# Altium schematic sheet EVB-NORA-B2_SCHEM_0006_PinHeaders_ULED.SchDoc(EVB-NORA-B2_SCHEM_0006_PinHeaders_ULED)
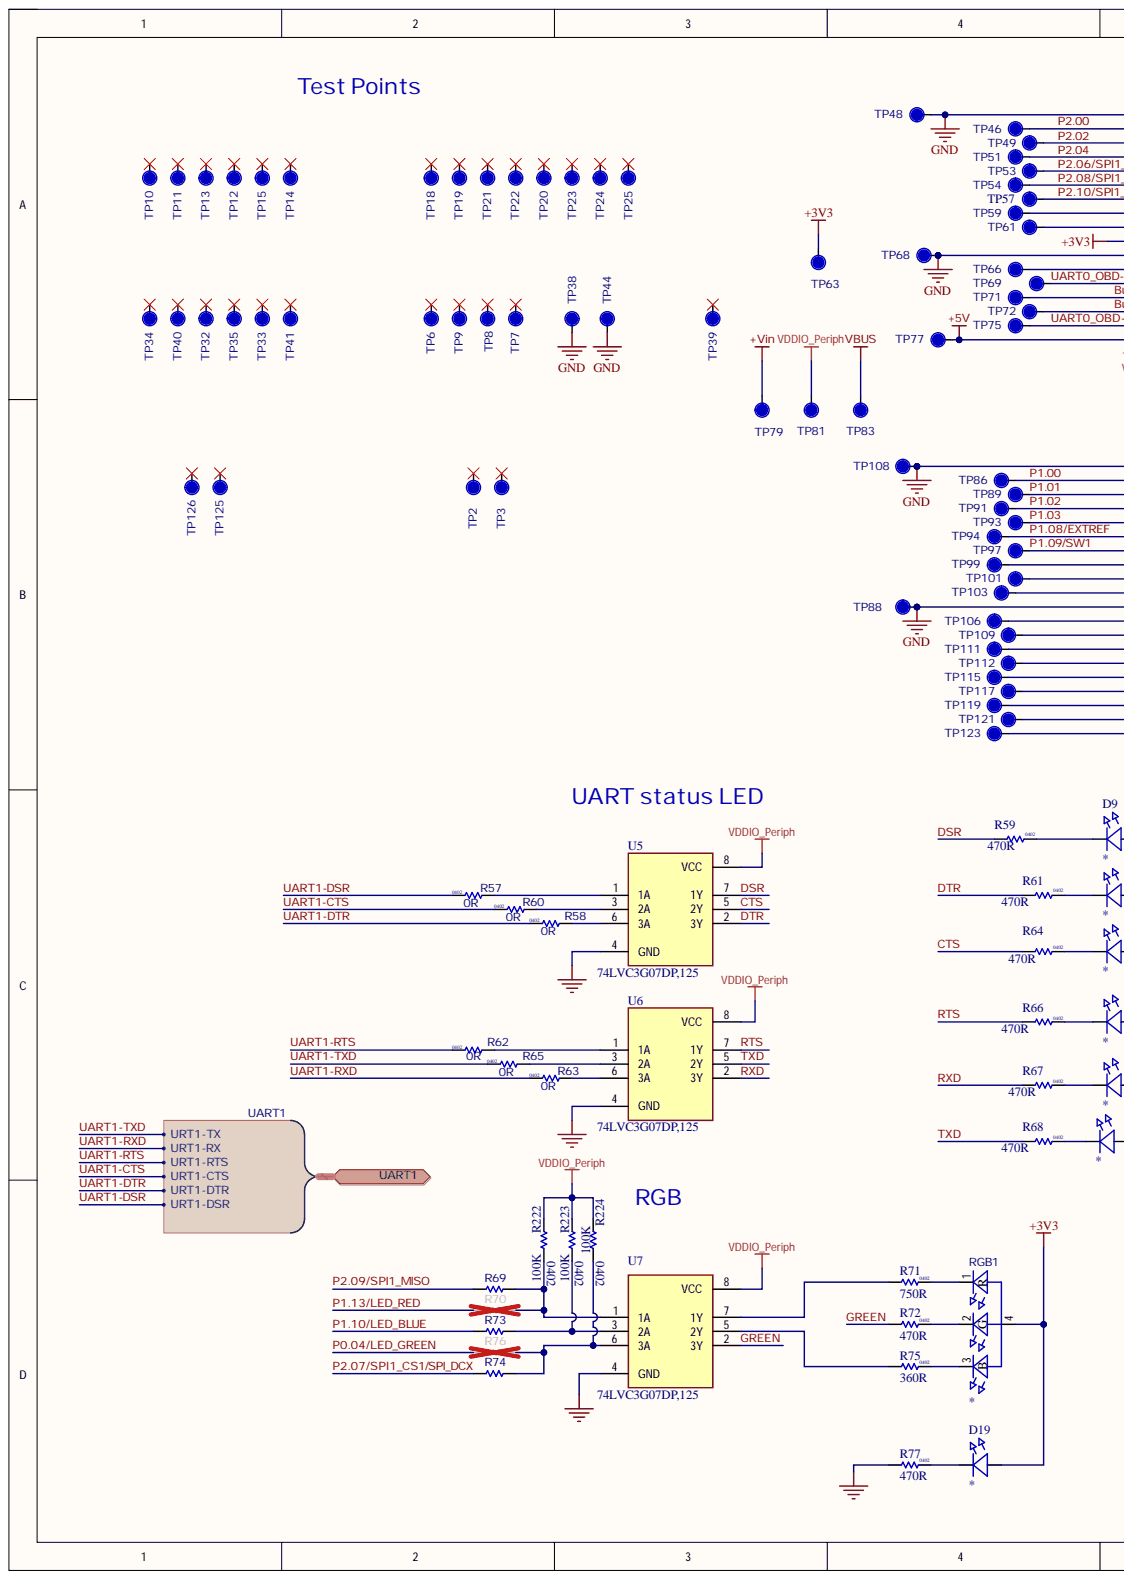
[diagram p0: EVB-NORA-B2_SCHEM_0006_PinHeaders_ULED.SchDoc, left]
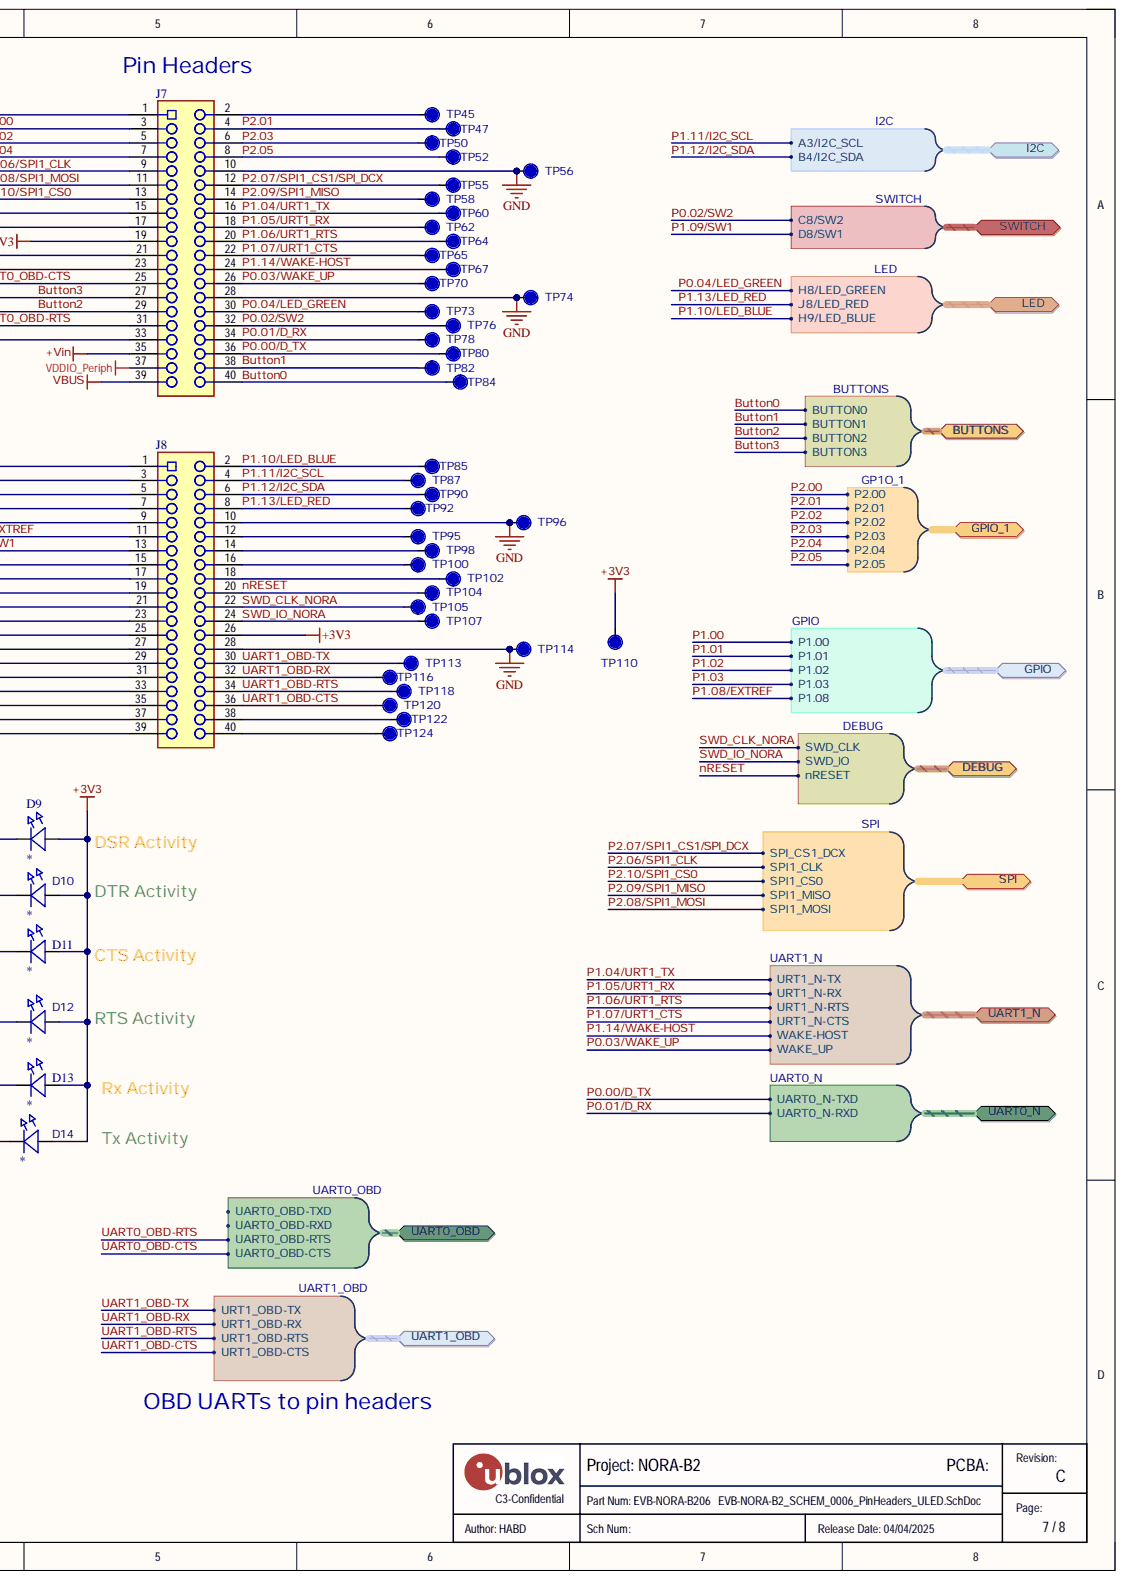
[diagram p1: EVB-NORA-B2_SCHEM_0006_PinHeaders_ULED.SchDoc, right]

## Parts
D9  UBXH11-0000057  [LED_0603_YELLOW]  (value not drawn)
D10  UBXH11-0000001  [LED_0603_GREEN]  (value not drawn)
D11  UBXH11-0000057  [LED_0603_YELLOW]  (value not drawn)
D12  UBXH11-0000001  [LED_0603_GREEN]  (value not drawn)
D13  UBXH11-0000057  [LED_0603_YELLOW]  (value not drawn)
D14  UBXH11-0000001  [LED_0603_GREEN]  (value not drawn)
D19  UBXH11-0000018  [LED_0603_1600Lx800Wx400H_600x800Pad_800G_FLX]  (value not drawn)
J7  (no value)  [M208762042]
J8  (no value)  [M208762042]
R57  value 0R  Size: 0402  [RES 0402 HD]  (hidden comment: UBXH18-0003002)
R58  value 0R  Size: 0402  [RES 0402 HD]
R59  value 470R  Size: 0402  [RES_0402_1100Lx600Wx400H_500x600Pad_400G_FLX]  (hidden comment: UBXH18-0008041)
R60  value 0R  Size: 0402  [RES 0402 HD]  (hidden comment: UBXH18-0003002)
R61  value 470R  Size: 0402  [RES_0402_1100Lx600Wx400H_500x600Pad_400G_FLX]  (hidden comment: UBXH18-0008041)
R62  value 0R  Size: 0402  [RES 0402 HD]  (hidden comment: UBXH18-0003002)
R63  value 0R  Size: 0402  [RES 0402 HD]  (hidden comment: UBXH18-0003002)
R64  value 470R  Size: 0402  [RES_0402_1100Lx600Wx400H_500x600Pad_400G_FLX]  (hidden comment: UBXH18-0008041)
R65  value 0R  Size: 0402  [RES 0402 HD]  (hidden comment: UBXH18-0003002)
R66  value 470R  Size: 0402  [RES_0402_1100Lx600Wx400H_500x600Pad_400G_FLX]  (hidden comment: UBXH18-0008041)
R67  value 470R  Size: 0402  [RES_0402_1100Lx600Wx400H_500x600Pad_400G_FLX]  (hidden comment: UBXH18-0008041)
R68  value 470R  Size: 0402  [RES_0402_1100Lx600Wx400H_500x600Pad_400G_FLX]  (hidden comment: UBXH18-0008041)
R69  UBXH18-0003002  [RES 0402 HD]  (value not drawn)
R70  = "Part Number" + Value + DNP  [RES 0402 HD]
R71  value 750R  Size: 0402  [RES_0402_1100Lx600Wx400H_500x600Pad_400G_FLX]  (hidden comment: UBXH18-0008046)
R72  value 470R  Size: 0402  [RES_0402_1100Lx600Wx400H_500x600Pad_400G_FLX]  (hidden comment: UBXH18-0008041)
R73  UBXH18-0003002  [RES 0402 HD]  (value not drawn)
R74  UBXH18-0003002  [RES 0402 HD]  (value not drawn)
R75  value 360R  Size: 0402  [RES_0402_1100Lx600Wx400H_500x600Pad_400G_FLX]  (hidden comment: UBXH18-0000216)
R76  = "Part Number" + Value + DNP  [RES 0402 HD]
R77  value 470R  Size: 0402  [RES_0402_1100Lx600Wx400H_500x600Pad_400G_FLX]  (hidden comment: UBXH18-0008041)
R222  value 100K  Size: 0402  [RES 0402 HD]  (hidden comment: UBXH18-0000005)
R223  value 100K  Size: 0402  [RES 0402 HD]  (hidden comment: UBXH18-0000005)
R224  value 100K  Size: 0402  [RES 0402 HD]  (hidden comment: UBXH18-0000005)
RGB1  115-00026  [LED_1616]  (value not drawn)
TP2  TP10R  [TP10R]  (value not drawn)  (no BOM)
TP3  TP10R  [TP10R]  (value not drawn)  (no BOM)
TP6  TP10R  [TP10R]  (value not drawn)  (no BOM)
TP7  TP10R  [TP10R]  (value not drawn)  (no BOM)
TP8  TP10R  [TP10R]  (value not drawn)  (no BOM)
TP9  TP10R  [TP10R]  (value not drawn)  (no BOM)
TP10  TP10R  [TP10R]  (value not drawn)  (no BOM)
TP11  TP10R  [TP10R]  (value not drawn)  (no BOM)
TP12  TP10R  [TP10R]  (value not drawn)  (no BOM)
TP13  TP10R  [TP10R]  (value not drawn)  (no BOM)
TP14  TP10R  [TP10R]  (value not drawn)  (no BOM)
TP15  TP10R  [TP10R]  (value not drawn)  (no BOM)
TP18  TP10R  [TP10R]  (value not drawn)  (no BOM)
TP19  TP10R  [TP10R]  (value not drawn)  (no BOM)
TP20  TP10R  [TP10R]  (value not drawn)  (no BOM)
TP21  TP10R  [TP10R]  (value not drawn)  (no BOM)
TP22  TP10R  [TP10R]  (value not drawn)  (no BOM)
TP23  TP10R  [TP10R]  (value not drawn)  (no BOM)
TP24  TP10R  [TP10R]  (value not drawn)  (no BOM)
TP25  TP10R  [TP10R]  (value not drawn)  (no BOM)
TP32  TP10R  [TP10R]  (value not drawn)  (no BOM)
TP33  TP10R  [TP10R]  (value not drawn)  (no BOM)
TP34  TP10R  [TP10R]  (value not drawn)  (no BOM)
TP35  TP10R  [TP10R]  (value not drawn)  (no BOM)
TP38  TP10R  [TP10R]  (value not drawn)  (no BOM)
TP39  TP10R  [TP10R]  (value not drawn)  (no BOM)
TP40  TP10R  [TP10R]  (value not drawn)  (no BOM)
TP41  TP10R  [TP10R]  (value not drawn)  (no BOM)
TP44  TP10R  [TP10R]  (value not drawn)  (no BOM)
TP45  TP10R  [TP10R]  (value not drawn)  (no BOM)
TP46  TP10R  [TP10R]  (value not drawn)  (no BOM)
TP47  TP10R  [TP10R]  (value not drawn)  (no BOM)
TP48  TP10R  [TP10R]  (value not drawn)  (no BOM)
TP49  TP10R  [TP10R]  (value not drawn)  (no BOM)
TP50  TP10R  [TP10R]  (value not drawn)  (no BOM)
TP51  TP10R  [TP10R]  (value not drawn)  (no BOM)
TP52  TP10R  [TP10R]  (value not drawn)  (no BOM)
TP53  TP10R  [TP10R]  (value not drawn)  (no BOM)
TP54  TP10R  [TP10R]  (value not drawn)  (no BOM)
TP55  TP10R  [TP10R]  (value not drawn)  (no BOM)
TP56  TP10R  [TP10R]  (value not drawn)  (no BOM)
TP57  TP10R  [TP10R]  (value not drawn)
TP58  TP10R  [TP10R]  (value not drawn)  (no BOM)
TP59  TP10R  [TP10R]  (value not drawn)  (no BOM)
TP60  TP10R  [TP10R]  (value not drawn)  (no BOM)
TP61  TP10R  [TP10R]  (value not drawn)  (no BOM)
TP62  TP10R  [TP10R]  (value not drawn)  (no BOM)
TP63  TP10R  [TP10R]  (value not drawn)  (no BOM)
TP64  TP10R  [TP10R]  (value not drawn)  (no BOM)
TP65  TP10R  [TP10R]  (value not drawn)  (no BOM)
TP66  TP10R  [TP10R]  (value not drawn)  (no BOM)
TP67  TP10R  [TP10R]  (value not drawn)  (no BOM)
TP68  TP10R  [TP10R]  (value not drawn)  (no BOM)
TP69  TP10R  [TP10R]  (value not drawn)  (no BOM)
TP70  TP10R  [TP10R]  (value not drawn)  (no BOM)
TP71  TP10R  [TP10R]  (value not drawn)  (no BOM)
TP72  TP10R  [TP10R]  (value not drawn)  (no BOM)
TP73  TP10R  [TP10R]  (value not drawn)  (no BOM)
TP74  TP10R  [TP10R]  (value not drawn)  (no BOM)
TP75  TP10R  [TP10R]  (value not drawn)  (no BOM)
TP76  TP10R  [TP10R]  (value not drawn)  (no BOM)
TP77  TP10R  [TP10R]  (value not drawn)  (no BOM)
TP78  TP10R  [TP10R]  (value not drawn)  (no BOM)
TP79  TP10R  [TP10R]  (value not drawn)  (no BOM)
TP80  TP10R  [TP10R]  (value not drawn)  (no BOM)
TP81  TP10R  [TP10R]  (value not drawn)  (no BOM)
TP82  TP10R  [TP10R]  (value not drawn)  (no BOM)
TP83  TP10R  [TP10R]  (value not drawn)  (no BOM)
TP84  TP10R  [TP10R]  (value not drawn)  (no BOM)
TP85  TP10R  [TP10R]  (value not drawn)  (no BOM)
TP86  TP10R  [TP10R]  (value not drawn)  (no BOM)
TP87  TP10R  [TP10R]  (value not drawn)  (no BOM)
TP88  TP10R  [TP10R]  (value not drawn)  (no BOM)
TP89  TP10R  [TP10R]  (value not drawn)  (no BOM)
TP90  TP10R  [TP10R]  (value not drawn)  (no BOM)
TP91  TP10R  [TP10R]  (value not drawn)  (no BOM)
TP92  TP10R  [TP10R]  (value not drawn)  (no BOM)
TP93  TP10R  [TP10R]  (value not drawn)  (no BOM)
TP94  TP10R  [TP10R]  (value not drawn)  (no BOM)
TP95  TP10R  [TP10R]  (value not drawn)  (no BOM)
TP96  TP10R  [TP10R]  (value not drawn)  (no BOM)
TP97  TP10R  [TP10R]  (value not drawn)  (no BOM)
TP98  TP10R  [TP10R]  (value not drawn)  (no BOM)
TP99  TP10R  [TP10R]  (value not drawn)  (no BOM)
TP100  TP10R  [TP10R]  (value not drawn)  (no BOM)
TP101  TP10R  [TP10R]  (value not drawn)  (no BOM)
TP102  TP10R  [TP10R]  (value not drawn)  (no BOM)
TP103  TP10R  [TP10R]  (value not drawn)  (no BOM)
TP104  TP10R  [TP10R]  (value not drawn)  (no BOM)
TP105  TP10R  [TP10R]  (value not drawn)  (no BOM)
TP106  TP10R  [TP10R]  (value not drawn)  (no BOM)
TP107  TP10R  [TP10R]  (value not drawn)  (no BOM)
TP108  TP10R  [TP10R]  (value not drawn)  (no BOM)
TP109  TP10R  [TP10R]  (value not drawn)  (no BOM)
TP110  TP10R  [TP10R]  (value not drawn)  (no BOM)
TP111  TP10R  [TP10R]  (value not drawn)  (no BOM)
TP112  TP10R  [TP10R]  (value not drawn)  (no BOM)
TP113  TP10R  [TP10R]  (value not drawn)  (no BOM)
TP114  TP10R  [TP10R]  (value not drawn)  (no BOM)
TP115  TP10R  [TP10R]  (value not drawn)  (no BOM)
TP116  TP10R  [TP10R]  (value not drawn)  (no BOM)
TP117  TP10R  [TP10R]  (value not drawn)  (no BOM)
TP118  TP10R  [TP10R]  (value not drawn)  (no BOM)
TP119  TP10R  [TP10R]  (value not drawn)  (no BOM)
TP120  TP10R  [TP10R]  (value not drawn)  (no BOM)
TP121  TP10R  [TP10R]  (value not drawn)  (no BOM)
TP122  TP10R  [TP10R]  (value not drawn)  (no BOM)
TP123  TP10R  [TP10R]  (value not drawn)  (no BOM)
TP124  TP10R  [TP10R]  (value not drawn)  (no BOM)
TP125  TP10R  [TP10R]  (value not drawn)  (no BOM)
TP126  TP10R  [TP10R]  (value not drawn)  (no BOM)
U5  Arrow Part Number: 74LVC3G07DP,125  [SOP65P400X110-8N]  (hidden comment: UBXH06-0000120)
U6  Arrow Part Number: 74LVC3G07DP,125  [SOP65P400X110-8N]  (hidden comment: UBXH06-0000120)
U7  Arrow Part Number: 74LVC3G07DP,125  [SOP65P400X110-8N]  (hidden comment: UBXH06-0000120)

## Ports
BUTTONS, BUTTONS.BUTTON0, BUTTONS.BUTTON1, BUTTONS.BUTTON2, BUTTONS.BUTTON3, DEBUG, DEBUG.nRESET, DEBUG.SWD_CLK, DEBUG.SWD_IO, GPIO, GPIO.P1.00, GPIO.P1.01, GPIO.P1.02, GPIO.P1.03, GPIO.P1.08, GPIO_1, GPIO_1.P2.00, GPIO_1.P2.01, GPIO_1.P2.02, GPIO_1.P2.03, GPIO_1.P2.04, GPIO_1.P2.05, I2C, I2C.A3/I2C_SCL, I2C.B4/I2C_SDA, LED, LED.H8/LED_GREEN, LED.H9/LED_BLUE, LED.J8/LED_RED, SPI, SPI.SPI1_CLK, SPI.SPI1_CS0, SPI.SPI1_MISO, SPI.SPI1_MOSI, SPI.SPI_CS1_DCX, SWITCH, SWITCH.C8/SW2, SWITCH.D8/SW1, UART0_N, UART0_N.UART0_N-RXD, UART0_N.UART0_N-TXD, UART0_OBD, UART0_OBD.UART0_OBD-CTS, UART0_OBD.UART0_OBD-RTS, UART0_OBD.UART0_OBD-RXD, UART0_OBD.UART0_OBD-TXD, UART1, UART1.URT1-CTS, UART1.URT1-DSR, UART1.URT1-DTR, UART1.URT1-RTS, UART1.URT1-RX, UART1.URT1-TX, UART1_N, UART1_N.URT1_N-CTS, UART1_N.URT1_N-RTS, UART1_N.URT1_N-RX, UART1_N.URT1_N-TX, UART1_N.WAKE-HOST, UART1_N.WAKE_UP, UART1_OBD, UART1_OBD.URT1_OBD-CTS, UART1_OBD.URT1_OBD-RTS, UART1_OBD.URT1_OBD-RX, UART1_OBD.URT1_OBD-TX

## Nets
+3V3: D9.2 D10.2 D11.2 D12.2 D13.2 D14.2 D19.2 J7.19 J8.26 RGB1.4 TP63.1 TP110.1
+5V: J7.33 TP77.1
+Vin: J7.35 TP79.1
GND: J7.1 J7.10 J7.21 J7.28 J8.1 J8.10 J8.21 J8.28 R77.1 TP38.1 TP44.1 TP48.1 TP56.1 TP68.1 TP74.1 TP88.1 TP96.1 TP108.1 TP114.1 U5.4(GND) U6.4(GND) U7.4(GND)
VBUS: J7.39 TP83.1
VDDIO_Periph: J7.37 R222.1 R223.1 R224.1 TP81.1 U5.8(VCC) U6.8(VCC) U7.8(VCC)
Button0: J7.40 TP84.1 ; port BUTTONS
Button1: J7.38 TP82.1 ; port BUTTONS
Button2: J7.29 TP72.1 ; port BUTTONS
Button3: J7.27 TP71.1 ; port BUTTONS
CTS: R64.1 U5.5(2Y)
DSR: R59.1 U5.7(1Y)
DTR: R61.1 U5.2(3Y)
GREEN: R72.1 U7.2(3Y)
nRESET: J8.20 TP104.1 ; port DEBUG
P0.00/D_TX: J7.36 TP80.1 ; port UART0_N
P0.01/D_RX: J7.34 TP78.1 ; port UART0_N
P0.02/SW2: J7.32 TP76.1 ; port SWITCH
P0.03/WAKE_UP: J7.26 TP70.1 ; port UART1_N
P0.04/LED_GREEN: J7.30 R76.2 TP73.1 ; port LED
P1.00: J8.3 TP86.1 ; port GPIO
P1.01: J8.5 TP89.1 ; port GPIO
P1.02: J8.7 TP91.1 ; port GPIO
P1.03: J8.9 TP93.1 ; port GPIO
P1.04/URT1_TX: J7.16 TP60.1 ; port UART1_N
P1.05/URT1_RX: J7.18 TP62.1 ; port UART1_N
P1.06/URT1_RTS: J7.20 TP64.1 ; port UART1_N
P1.07/URT1_CTS: J7.22 TP65.1 ; port UART1_N
P1.08/EXTREF: J8.11 TP94.1 ; port GPIO
P1.09/SW1: J8.13 TP97.1 ; port SWITCH
P1.10/LED_BLUE: J8.2 R73.2 TP85.1 ; port LED
P1.11/I2C_SCL: J8.4 TP87.1 ; port I2C
P1.12/I2C_SDA: J8.6 TP90.1 ; port I2C
P1.13/LED_RED: J8.8 R70.2 TP92.1 ; port LED
P1.14/WAKE-HOST: J7.24 TP67.1 ; port UART1_N
P2.00: J7.3 TP46.1 ; port GPIO_1
P2.01: J7.4 TP47.1 ; port GPIO_1
P2.02: J7.5 TP49.1 ; port GPIO_1
P2.03: J7.6 TP50.1 ; port GPIO_1
P2.04: J7.7 TP51.1 ; port GPIO_1
P2.05: J7.8 TP52.1 ; port GPIO_1
P2.06/SPI1_CLK: J7.9 TP53.1 ; port SPI
P2.07/SPI1_CS1/SPI_DCX: J7.12 R74.2 TP55.1 ; port SPI
P2.08/SPI1_MOSI: J7.11 TP54.1 ; port SPI
P2.09/SPI1_MISO: J7.14 R69.2 TP58.1 ; port SPI
P2.10/SPI1_CS0: J7.13 TP57.1 ; port SPI
RTS: R66.1 U6.7(1Y)
RXD: R67.1 U6.2(3Y)
SWD_CLK_NORA: J8.22 TP105.1 ; port DEBUG
SWD_IO_NORA: J8.24 TP107.1 ; port DEBUG
TXD: R68.1 U6.5(2Y)
UART0_OBD-CTS: J7.25 TP69.1 ; port UART0_OBD
UART0_OBD-RTS: J7.31 TP75.1 ; port UART0_OBD
UART1-CTS: R60.2 ; port UART1
UART1-DSR: R57.2 ; port UART1
UART1-DTR: R58.2 ; port UART1
UART1-RTS: R62.2 ; port UART1
UART1-RXD: R63.2 ; port UART1
UART1-TXD: R65.2 ; port UART1
UART1_OBD-CTS: J8.36 TP120.1 ; port UART1_OBD
UART1_OBD-RTS: J8.34 TP118.1 ; port UART1_OBD
UART1_OBD-RX: J8.32 TP116.1 ; port UART1_OBD
UART1_OBD-TX: J8.30 TP113.1 ; port UART1_OBD
NetD9_1: D9.1 R59.2
NetD10_1: D10.1 R61.2
NetD11_1: D11.1 R64.2
NetD12_1: D12.1 R66.2
NetD13_1: D13.1 R67.2
NetD14_1: D14.1 R68.2
NetD19_1: D19.1 R77.2
NetJ7_2: J7.2 TP45.1
NetJ7_15: J7.15 TP59.1
NetJ7_17: J7.17 TP61.1
NetJ7_23: J7.23 TP66.1
NetJ8_12: J8.12 TP95.1
NetJ8_14: J8.14 TP98.1
NetJ8_15: J8.15 TP99.1
NetJ8_16: J8.16 TP100.1
NetJ8_17: J8.17 TP101.1
NetJ8_18: J8.18 TP102.1
NetJ8_19: J8.19 TP103.1
NetJ8_23: J8.23 TP106.1
NetJ8_25: J8.25 TP109.1
NetJ8_27: J8.27 TP111.1
NetJ8_29: J8.29 TP112.1
NetJ8_31: J8.31 TP115.1
NetJ8_33: J8.33 TP117.1
NetJ8_35: J8.35 TP119.1
NetJ8_37: J8.37 TP121.1
NetJ8_38: J8.38 TP122.1
NetJ8_39: J8.39 TP123.1
NetJ8_40: J8.40 TP124.1
NetR57_1: R57.1 U5.1(1A)
NetR58_1: R58.1 U5.6(3A)
NetR60_1: R60.1 U5.3(2A)
NetR62_1: R62.1 U6.1(1A)
NetR63_1: R63.1 U6.6(3A)
NetR65_1: R65.1 U6.3(2A)
NetR69_1: R69.1 R70.1 R222.2 U7.1(1A)
NetR71_1: R71.1 U7.7(1Y)
NetR71_2: R71.2 RGB1.1(R)
NetR72_2: R72.2 RGB1.2(G)
NetR73_1: R73.1 R223.2 U7.3(2A)
NetR74_1: R74.1 R76.1 R224.2 U7.6(3A)
NetR75_1: R75.1 U7.5(2Y)
NetR75_2: R75.2 RGB1.3(B)
N00022: ; port UART0_OBD
N00023: ; port UART0_OBD

Not connected: TP2 1; TP3 1; TP6 1; TP7 1; TP8 1; TP9 1; TP10 1; TP11 1; TP12 1; TP13 1; TP14 1; TP15 1; TP18 1; TP19 1; TP20 1; TP21 1; TP22 1; TP23 1; TP24 1; TP25 1; TP32 1; TP33 1; TP34 1; TP35 1; TP39 1; TP40 1; TP41 1; TP125 1; TP126 1

## Library data of the parts
D9 D11 D13 = UBXH11-0000057: DIODE LED SMT YELLOW CLEAR LENS 2.1V 10MA 0603 YELLOW; Manufacturer: Lite-on Technology Corporation; Manufacturer P/N: LTST-C190YKT; Database Table Name: Discrete - LED; Editor: eric.moog; Env: GREEN; Mfg P/N Released: No; Mfg P/N Split: 100; Mfg P/N Status: Active; Permitted Use: Volume Products; Component Kind: Standard; Library Name: UBX_Parts_DB_Lib.DbLib; Max Operating Temp: 85°C; Mfg P/N Datasheet: https://wiki.u-blox.com/bin/view/Generic/Docs/DocumentSummary?index=38122; Min Operating Temp: -55°C; Part Number: UBXH11-0000057; Part Type: LED; Perferred: N/A; RefDes: DS; Size: 0603; UBX Wiki: https://wiki.u-blox.com/bin/view/Operations/PartDetails?index=38120; Value: YELLOW
D10 D12 D14 = UBXH11-0000001: LED SMD 0603 GREEN 2.5V 20mA; Manufacturer: Kingbright; Manufacturer P/N: APT1608SGC; Database Table Name: Discrete - LED; Editor: gianni.falleroni; Env: ROHS; Mfg P/N Released: Yes; Mfg P/N Split: 50; Mfg P/N Status: Active; Permitted Use: Support Products; Released by: alex.claville; Component Kind: Standard; Library Name: UBX_Parts_DB_Lib.DbLib; Manufacturer 2: Lite-on Technology Corporation; Manufacturer P/N 2: LTST-C190KGKT; Max Current: 20mA; Max Voltage: 2.5V; Mfg P/N Datasheet: https://wiki.u-blox.com/bin/view/Generic/Docs/DocumentSummary?index=37527; Mfg P/N Datasheet 2: https://wiki.u-blox.com/bin/view/Generic/Docs/DocumentSummary?index=16220; Mfg P/N Released 2: Yes; Mfg P/N Split 2: 50; Mfg P/N Status 2: Active; Part Number: UBXH11-0000001; Part Type: LED; Perferred: N/A; RefDes: DS; Release Data: 2022-09-27T14:26:54; Size: 0603; UBX Wiki: https://wiki.u-blox.com/bin/view/Operations/PartDetails?index=7902; Value: GREEN
D19 = UBXH11-0000018: LED CHIP 0603 LOW CURRENT OSRAM 0.002A GREEN 2.2V 2mA; Manufacturer P/N: LG L29K-G2J1-24; Database Table Name: Discrete - LED; Editor: johann.furrer; Env: ROHS; Mfg P/N Released: Yes; Mfg P/N Split: 100; Mfg P/N Status: NRND; Perferred: PREF; Permitted Use: Volume Products; Released by: martin.grasse; Component Kind: Standard; Library Name: UBX_Parts_DB_Lib.DbLib; Manufacturer: Osram; Max Current: 2mA; Max Operating Temp: 85°C; Max Voltage: 2.2V; Mfg P/N Datasheet: https://wiki.u-blox.com/bin/view/Generic/Docs/DocumentSummary?index=20620; Min Operating Temp: -40°C; Part Number: UBXH11-0000018; Part Type: LED; RefDes: DS; Release Data: 2018-01-09T15:31:38; Size: 0603; UBX Wiki: https://wiki.u-blox.com/bin/view/Operations/PartDetails?index=20619; Value: GREEN
J7 J8 = M20-8762042: Connector; Manufacturer_Name: Harwin; Component Kind: Standard; Datasheet Link: https://cdn.harwin.com/pdfs/M20-876.pdf; Height: 10.01mm; Library Name: SamacSys.SchLib; Manufacturer_Part_Number: M20-8762042; Mouser Part Number: 855-M20-8762042; Mouser Price/Stock: https://www.mouser.co.uk/ProductDetail/Harwin/M20-8762042?qs=vF78I%252BjhbY%2F5WnwPzhFszw%3D%3D
R57 R58 R60 R62 R63 R65 R69 R70 R73 R74 R76 = UBXH18-0003002: RES THICK FILM CHIP 0402 0R 0 100mW; Manufacturer: KOA; Manufacturer P/N: RK73Z1ETTP; Database Table Name: Passive - Resistor; Editor: charlotte.biber; Env: ROHS; Mfg P/N Released: Yes; Mfg P/N Split: 100; Mfg P/N Status: NRND; Perferred: PREF; Permitted Use: Volume Products; Released by: peter.mueller; Tolerance: 0; Component Kind: Standard; Library Name: UBX_Parts_DB_Lib.DbLib; Manufacturer 2: YAGEO; Manufacturer P/N 2: 2322_705_91001_L; Max Power: 100mW; Mfg P/N Released 2: Yes; Mfg P/N Split 2: 0; Mfg P/N Status 2: NRND; Part Number: UBXH18-0003002; Part Type: Resistor; RefDes: R; Release Data: 2012-06-05 09:49:55.978616; Signal Integrity: Res; Simulation: RESISTOR; UBX Wiki: https://wiki.u-blox.com/bin/view/Operations/PartDetails?index=6611
  R58: Comment: = "Part Number" + Value + DNP
  R69 R70 R73 R74 R76: Size: 0402; Value: 0R
R59 R61 R64 R66-R68 R72 R77 = UBXH18-0008041: RES THICK FILM CHIP 0402 470R 5% 100mW; Manufacturer: ROHM; Manufacturer P/N: MCR01MZPJ471; Database Table Name: Passive - Resistor; Editor: roland.rust; Env: ROHS; Mfg P/N Released: Yes; Mfg P/N Split: 100; Mfg P/N Status: Obsolete; Perferred: PREF; Permitted Use: Volume Products; Component Kind: Standard; Library Name: UBX_Parts_DB_Lib.DbLib; Manufacturer 2: KOA; Manufacturer 3: YAGEO; Manufacturer P/N 2: RK73B1ETTP471J; Manufacturer P/N 3: 2322_705_87471_L; Max Power: 100mW; Mfg P/N Released 2: Yes; Mfg P/N Released 3: Yes; Mfg P/N Split 2: 0; Mfg P/N Split 3: 0; Mfg P/N Status 2: Active; Mfg P/N Status 3: Obsolete; Part Number: UBXH18-0008041; Part Type: Resistor; RefDes: R; Release Data: 2009-04-24T14:36:39; Signal Integrity: Res; Simulation: RESISTOR; Tolerance: 5%; UBX Wiki: https://wiki.u-blox.com/bin/view/Operations/PartDetails?index=6926; Released by: roland.rust
R71 = UBXH18-0008046: RES THICK FILM CHIP 0402 750R 5% 100mW; Manufacturer: Panasonic; Manufacturer P/N: ERJ2GEJ751X; Database Table Name: Passive - Resistor; Editor: jaka.bevk; Env: ROHS; Mfg P/N Released: Yes; Mfg P/N Split: 100; Mfg P/N Status: Active; Perferred: PREF; Permitted Use: Volume Products; Released by: erian.bezhani; Component Kind: Standard; Library Name: UBX_Parts_DB_Lib.DbLib; Max Power: 100mW; Mfg P/N Datasheet: https://wiki.u-blox.com/bin/view/Generic/Docs/DocumentSummary?index=21267; Part Number: UBXH18-0008046; Part Type: Resistor; RefDes: R; Release Data: 2014-10-03T09:31:33; Signal Integrity: Res; Simulation: RESISTOR; Tolerance: 5%; UBX Wiki: https://wiki.u-blox.com/bin/view/Operations/PartDetails?index=6935
R75 = UBXH18-0000216: RES THICK FILM CHIP 0402 360R 1% 63mW; Manufacturer: KOA; Manufacturer P/N: RK73H1ETTP3600F; Database Table Name: Passive - Resistor; Editor: michael.hein; Env: ROHS; Mfg P/N Released: Yes; Mfg P/N Split: 100; Mfg P/N Status: Active; Perferred: PREF; Permitted Use: Volume Products; Released by: vasyl.dykyy; Automotive: Yes; Component Kind: Standard; Library Name: UBX_Parts_DB_Lib.DbLib; Max Power: 63mW; Mfg P/N Datasheet: https://wiki.u-blox.com/bin/view/Generic/Docs/DocumentSummary?index=8222; Part Number: UBXH18-0000216; Part Type: Resistor; RefDes: R; Release Data: 2015-10-02T11:55:45; Signal Integrity: Res; Simulation: RESISTOR; Tolerance: 1%; UBX Wiki: https://wiki.u-blox.com/bin/view/Operations/PartDetails?index=23306
R222-R224 = UBXH18-0000005: RES THICK FILM CHIP 0402 100K 1% 63mW; Manufacturer: KOA; Manufacturer P/N: RK73H1ETTP1003F; Database Table Name: Passive - Resistor; Editor: dario.antonaz; Env: ROHS; Mfg P/N Released: Yes; Mfg P/N Split: 100; Mfg P/N Status: Active; Permitted Use: Volume Products; Released by: sandro.sestan; Case/Package: 0x0y; Component Kind: Standard; Library Name: UBX_Parts_DB_Lib.DbLib; Manufacturer 2: YAGEO; Manufacturer 3: ROHM; Manufacturer P/N 2: RC0402FR-07100KL; Manufacturer P/N 3: MCR01MZPF1003; Max Power: 63mW; Mfg P/N Datasheet: https://wiki.u-blox.com/bin/view/Generic/Docs/DocumentSummary?index=8222; Mfg P/N Datasheet 2: https://wiki.u-blox.com/bin/view/Generic/Docs/DocumentSummary?index=29373; Mfg P/N Datasheet 3: https://wiki.u-blox.com/bin/view/Generic/Docs/DocumentSummary?index=8186; Mfg P/N Released 2: Yes; Mfg P/N Released 3: Yes; Mfg P/N Split 2: 0; Mfg P/N Split 3: 0; Mfg P/N Status 2: Active; Mfg P/N Status 3: Obsolete; Part Number: UBXH18-0000005; Part Type: Resistor; Perferred: N/A; RefDes: R; Release Data: 2009-11-30T11:05:22; Signal Integrity: Res; Simulation: RESISTOR; Tolerance: 1%; UBX Wiki: https://wiki.u-blox.com/bin/view/Operations/PartDetails?index=8114
RGB1 = 115-00026: LED RGB SMT 0606; Manufacturer 1: Lite-On Inc; Manufacturer Part Number 1: LTST-C19HE1WT; Body Size: 1.6mm x 1.6mm; Current: 20mA; Item Phase: In Production; MFG Package: 0606; UBX Code: None; Use in new designs: Yes; Component Kind: Standard; Database Table Name: LED; Height: 0.35mm; Max Operating Temp: 80; Min Operating Temp: -20; Part Name: LED RGB SMT 0606; Part Number: 115-00026; Pins: 4; Revision: 0B; Size: Other; Supplier 1: Digi-Key; Supplier 2: Digi-Key; Supplier Part Number 1: 160-2162-1-ND; Supplier Part Number 2: 1516-1184-1-ND; Voltage: None; Library Name: Arena_Parts_DB_Lib.DbLib
TP2 TP3 TP6-TP15 TP18-TP25 TP32-TP35 TP38-TP41 TP44-TP126 = TP10R: Testpoint, Round 1.0mm Dia; Added By: Jeremy D; Database Table Name: Testpoints & Pads; DNP: DNP; Height: 0; Part Number: TP10R; Size: 1.0mm; Checked By: Paul B; Library Name: LEGACY_Rigado_AltiumLib.DbLib
  TP2 TP3 TP6-TP15 TP18-TP25 TP32-TP35 TP38-TP41 TP44-TP56 TP58-TP126: Added Date: 2/1/2015; Checked Date: 2/5/2015
  TP57: Added Date: 2015-02-01; Checked Date: 2015-02-05; Component Kind: Standard
U5-U7 = UBXH06-0000120: Non-inverting Buffers & Line Drivers 3 Channels /SOT505/TSSOP8 1.65V to 5.5V; Manufacturer 1: Nexperia; Manufacturer Part Number 1: 74LVC3G07DP; Manufacturer Split 1: 100; Part Phase: Unreleased; Body Size: 3.9mmx 3.1mm; Database Table Name: Integrated Circuit - Driver; Driver Type: LED; Environmental Grade: RoHS; Min Supply Voltage: 1.65; Owner: Hozaifa Abdelgadir; Single Source: No; SMT Pitch: 0.65mm; Use in new designs: Yes; Arrow Price/Stock: https://www.arrow.com/en/products/74lvc3g07dp125/nexperia?region=nac; Automotive: No; Case/Package: TSSOP-8; Component Kind: Standard; ComponentLink1Description: Arena Link; ComponentLink1Url: https://app.bom.com/3L5OTHPRLN6EXALGUBPR; Datasheet Link: https://assets.nexperia.com/documents/data-sheet/74LVC3G07.pdf; Height: 0.95mm; Manufacturer_Name: Nexperia; Manufacturer_Part_Number: 74LVC3G07DP,125; Max Operating Temp: 125°C; Max Supply Voltage: 5.5V; Min Operating Temp: -40°C; Mounting Type: SMT; Mouser Part Number: 771-74LVC3G07DP-G; Mouser Price/Stock: https://www.mouser.co.uk/ProductDetail/Nexperia/74LVC3G07DP125?qs=me8TqzrmIYXM25jpSFl3Hg%3D%3D; Part Name: Non-inverting Buffers & Line Drivers 3 Channels /SOT505/TSSOP8 1.65V to 5.5V; Part Number: UBXH06-0000120; Part Status: Preferred; Part Type: Driver; Pins: 8; Thermal Pad: No; Volume Use: No; Library Name: UBX_Arena.DbLib
